# Altium schematic sheet ICWSHLP2_Head_2x2_ASIC3.SchDoc(ICWSHLP2_Head_2x2_ASIC3)
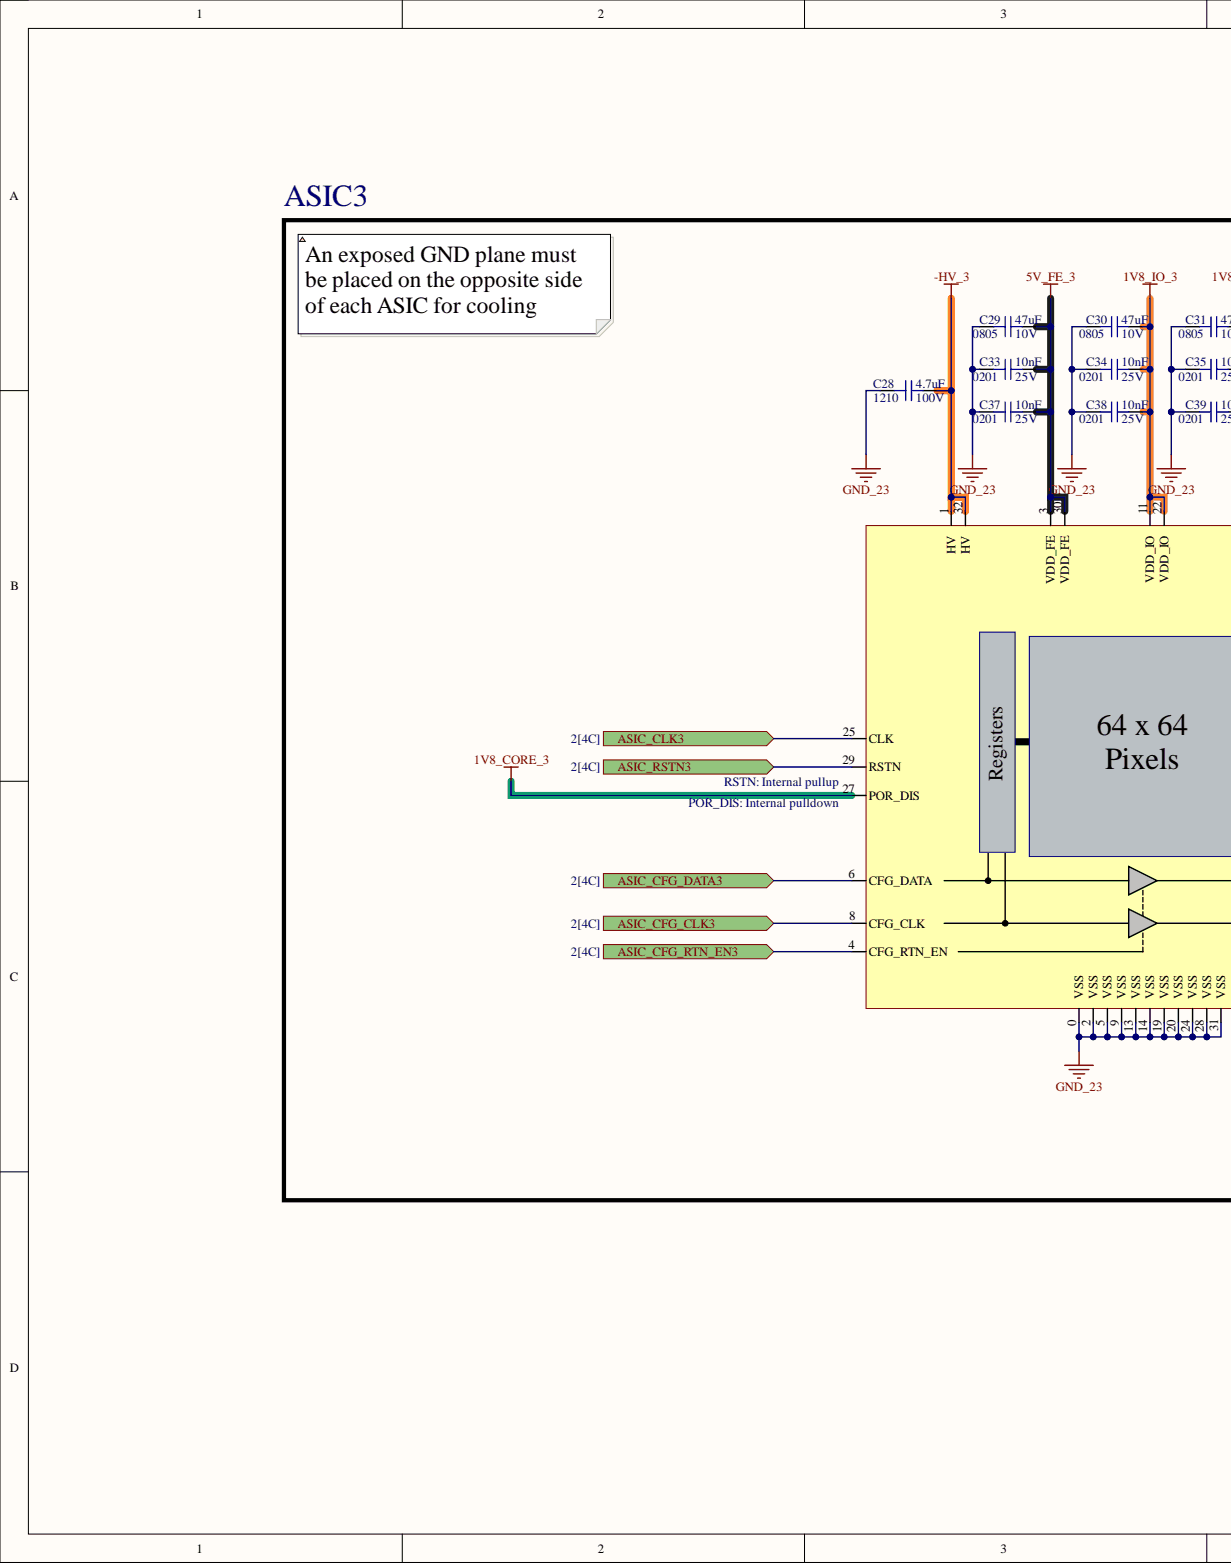
[diagram p0: ICWSHLP2_Head_2x2_ASIC3.SchDoc, left]
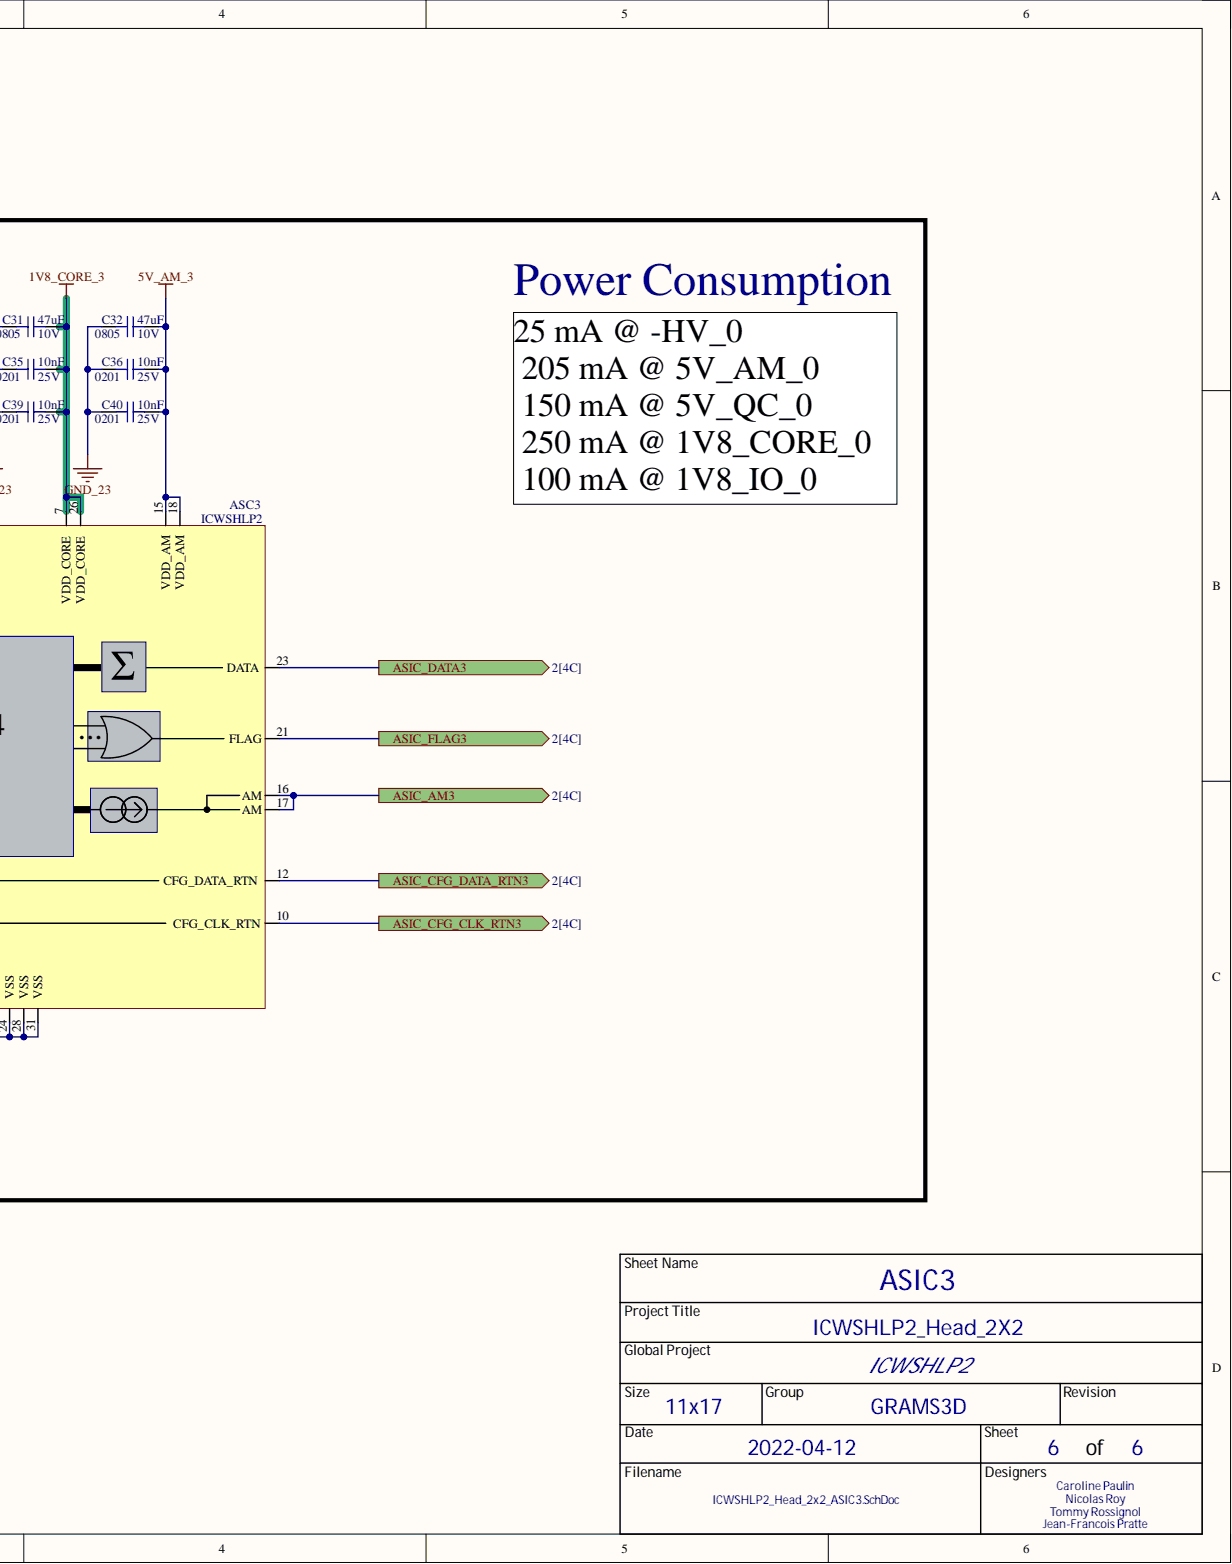
[diagram p1: ICWSHLP2_Head_2x2_ASIC3.SchDoc, right]

## Parts
ASC3  ICWSHLP2  [ASC_ICWSHLP2]
C28  value 4.7uF  Package: 1210  Voltage Rating: 100V  [CAP_CER_1210]  (hidden comment: GCM32DC72A475KE02L)
C29  value 47uF  Package: 0805  Voltage Rating: 10V  [CAP_CER_0805]  (hidden comment: GRM21BR61A476ME15L)
C30  value 47uF  Package: 0805  Voltage Rating: 10V  [CAP_CER_0805]  (hidden comment: GRM21BR61A476ME15L)
C31  value 47uF  Package: 0805  Voltage Rating: 10V  [CAP_CER_0805]  (hidden comment: GRM21BR61A476ME15L)
C32  value 47uF  Package: 0805  Voltage Rating: 10V  [CAP_CER_0805]  (hidden comment: GRM21BR61A476ME15L)
C33  value 10nF  Package: 0201  Voltage Rating: 25V  [CAP_CER_0201]  (hidden comment: TMK063B7103KP-F)
C34  value 10nF  Package: 0201  Voltage Rating: 25V  [CAP_CER_0201]  (hidden comment: TMK063B7103KP-F)
C35  value 10nF  Package: 0201  Voltage Rating: 25V  [CAP_CER_0201]  (hidden comment: TMK063B7103KP-F)
C36  value 10nF  Package: 0201  Voltage Rating: 25V  [CAP_CER_0201]  (hidden comment: TMK063B7103KP-F)
C37  value 10nF  Package: 0201  Voltage Rating: 25V  [CAP_CER_0201]  (hidden comment: TMK063B7103KP-F)
C38  value 10nF  Package: 0201  Voltage Rating: 25V  [CAP_CER_0201]  (hidden comment: TMK063B7103KP-F)
C39  value 10nF  Package: 0201  Voltage Rating: 25V  [CAP_CER_0201]  (hidden comment: TMK063B7103KP-F)
C40  value 10nF  Package: 0201  Voltage Rating: 25V  [CAP_CER_0201]  (hidden comment: TMK063B7103KP-F)

## Ports
ASIC_AM3, ASIC_CFG_CLK3, ASIC_CFG_CLK_RTN3, ASIC_CFG_DATA3, ASIC_CFG_DATA_RTN3, ASIC_CFG_RTN_EN3, ASIC_CLK3, ASIC_DATA3, ASIC_FLAG3, ASIC_RSTN3

## Nets
1V8_CORE_3: ASC3.7(VDD_CORE) ASC3.26(VDD_CORE) ASC3.27(POR_DIS) C31.2 C35.1 C39.1
1V8_IO_3: ASC3.11(VDD_IO) ASC3.22(VDD_IO) C30.2 C34.1 C38.1
5V_AM_3: ASC3.15(VDD_AM) ASC3.18(VDD_AM) C32.2 C36.1 C40.1
5V_FE_3: ASC3.3(VDD_FE) ASC3.30(VDD_FE) C29.2 C33.1 C37.1
-HV_3: ASC3.1(HV) ASC3.32(HV) C28.1
GND_23: ASC3.0(VSS) ASC3.2(VSS) ASC3.5(VSS) ASC3.9(VSS) ASC3.13(VSS) ASC3.14(VSS) ASC3.19(VSS) ASC3.20(VSS) ASC3.24(VSS) ASC3.28(VSS) ASC3.31(VSS) C28.2 C29.1 C30.1 C31.1 C32.1 C33.2 C34.2 C35.2 C36.2 C37.2 C38.2 C39.2 C40.2
ASIC_AM3: ASC3.16(AM) ASC3.17(AM) ; port ASIC_AM3
ASIC_CFG_CLK3: ASC3.8(CFG_CLK) ; port ASIC_CFG_CLK3
ASIC_CFG_CLK_RTN3: ASC3.10(CFG_CLK_RTN) ; port ASIC_CFG_CLK_RTN3
ASIC_CFG_DATA3: ASC3.6(CFG_DATA) ; port ASIC_CFG_DATA3
ASIC_CFG_DATA_RTN3: ASC3.12(CFG_DATA_RTN) ; port ASIC_CFG_DATA_RTN3
ASIC_CFG_RTN_EN3: ASC3.4(CFG_RTN_EN) ; port ASIC_CFG_RTN_EN3
ASIC_CLK3: ASC3.25(CLK) ; port ASIC_CLK3
ASIC_DATA3: ASC3.23(DATA) ; port ASIC_DATA3
ASIC_FLAG3: ASC3.21(FLAG) ; port ASIC_FLAG3
ASIC_RSTN3: ASC3.29(RSTN) ; port ASIC_RSTN3

## Library data of the parts
ASC3 = ASC_ICWSHLP2: Rev 2. CMOS SPAD Readout for 3D PDC in Low Power Applications.; Manufacturer #1: TSMC 180 nm BCD; GRAMS Lib Spec: 2.7; Magnetic Material: No; Polarized: Yes; Voltage Rating: 1.8V/5.0V; Assembly Type: Wirebonding; Component Kind: Standard; Library Name: GRAMS_MASTER_LIB_SCH.SchLib; Package: Bare Die; Part Number #1: ICWSHLP2; Supplier 1: TSMC; Supplier Part Number 1: ICWSHLP2; Temperature: -200 to 125 degC; CreatedBy/Date: Nicolas Roy/24 sept. 2018; VerifiedBy: Nicolas Roy
C28 = CAP_CER_GCM32DC72A475KE02L: CAP CER 4.7UF 100V X7S 1210; Manufacturer #1: Murata Electronics; Cryo: UNKNOWN; GRAMS Lib Spec: 2.8; Polarized: NO; Tolerance: 5%; Assembly Type: SMD; Component Kind: Standard; HelpURL: https://search.murata.co.jp/Ceramy/image/img/A01X/G101/ENG/GCM32DC72A475KE02-01.pdf; Magnetic Material: UNKNOWN; Part Number #1: GCM32DC72A475KE02L; Solder Paste Type: UNKNOWN; Stencil Thickness (Recommended): UNKNOWN; Supplier 1: Digi-Key; Supplier Part Number 1: 490-14484-1-ND; Temperature: -55°C ~ 125°C; CreatedBy/Date: Thomas G-C 2021-07-01; Library Name: Lib_temp_Thomas_GC.SchLib
C29-C32 = CAP_CER_GRM21BR61A476ME15L: CAP - CER 47uF 20% 10V X5R - 0805; Manufacturer #1: Murata; Cryo: UNKNOWN; GRAMS Lib Spec: 2.8; Polarized: No; Assembly Type: SMD; Component Kind: Standard; HelpURL: http://search.murata.co.jp/Ceramy/image/img/A01X/G101/ENG/GRM21BR61A476ME15-01.pdf; Library Name: GRAMS_MASTER_LIB_SCH.SchLib; Magnetic Material: UNKNOWN; Part Number #1: GRM21BR61A476ME15L; Solder Paste Type: UNKNOWN; Stencil Thickness (Recommended): UNKNOWN; Supplier 1: Digi-Key; Supplier Part Number 1: 490-9961-1-ND; Temperature: -55°C ~ 85°C; Tolerance: 20%; CreatedBy/Date: Joel Lemay 2018-06-19; VerifiedBy: Caroline Paulin - 7 sept 2018
C33-C40 = CAP_CER_TMK063B7103KP-F: CAP - CER 10nF 10% 25V X7R - 0201; Manufacturer #1: Taiyo Yuden; Cryo: UNKNOWN; GRAMS Lib Spec: 2.8; Polarized: No; Solder Paste Type: TYPE 3; Stencil Thickness (Recommended): MAX 5 MILS; Assembly Type: SMD; Component Kind: Standard; HelpURL: http://ds.yuden.co.jp/TYCOMPAS/ut/detail.do?productNo=TMK063B7103KP-F&fileName=TMK063B7103KP-F_SS&mode=specSheetDownload; Library Name: GRAMS_MASTER_LIB_SCH.SchLib; Magnetic Material: UNKNOWN; Part Number #1: TMK063B7103KP-F; Supplier 1: Digi-Key; Supplier Part Number 1: 587-5943-1-ND; Temperature: -55°C ~ 125°C; Tolerance: 10%; CreatedBy/Date: Nicolas Roy - 13 dec. 2018; VerifiedBy: Nicolas V. (2019-01-22)
